# Altium schematic sheet Power input.SchDoc("Power input")
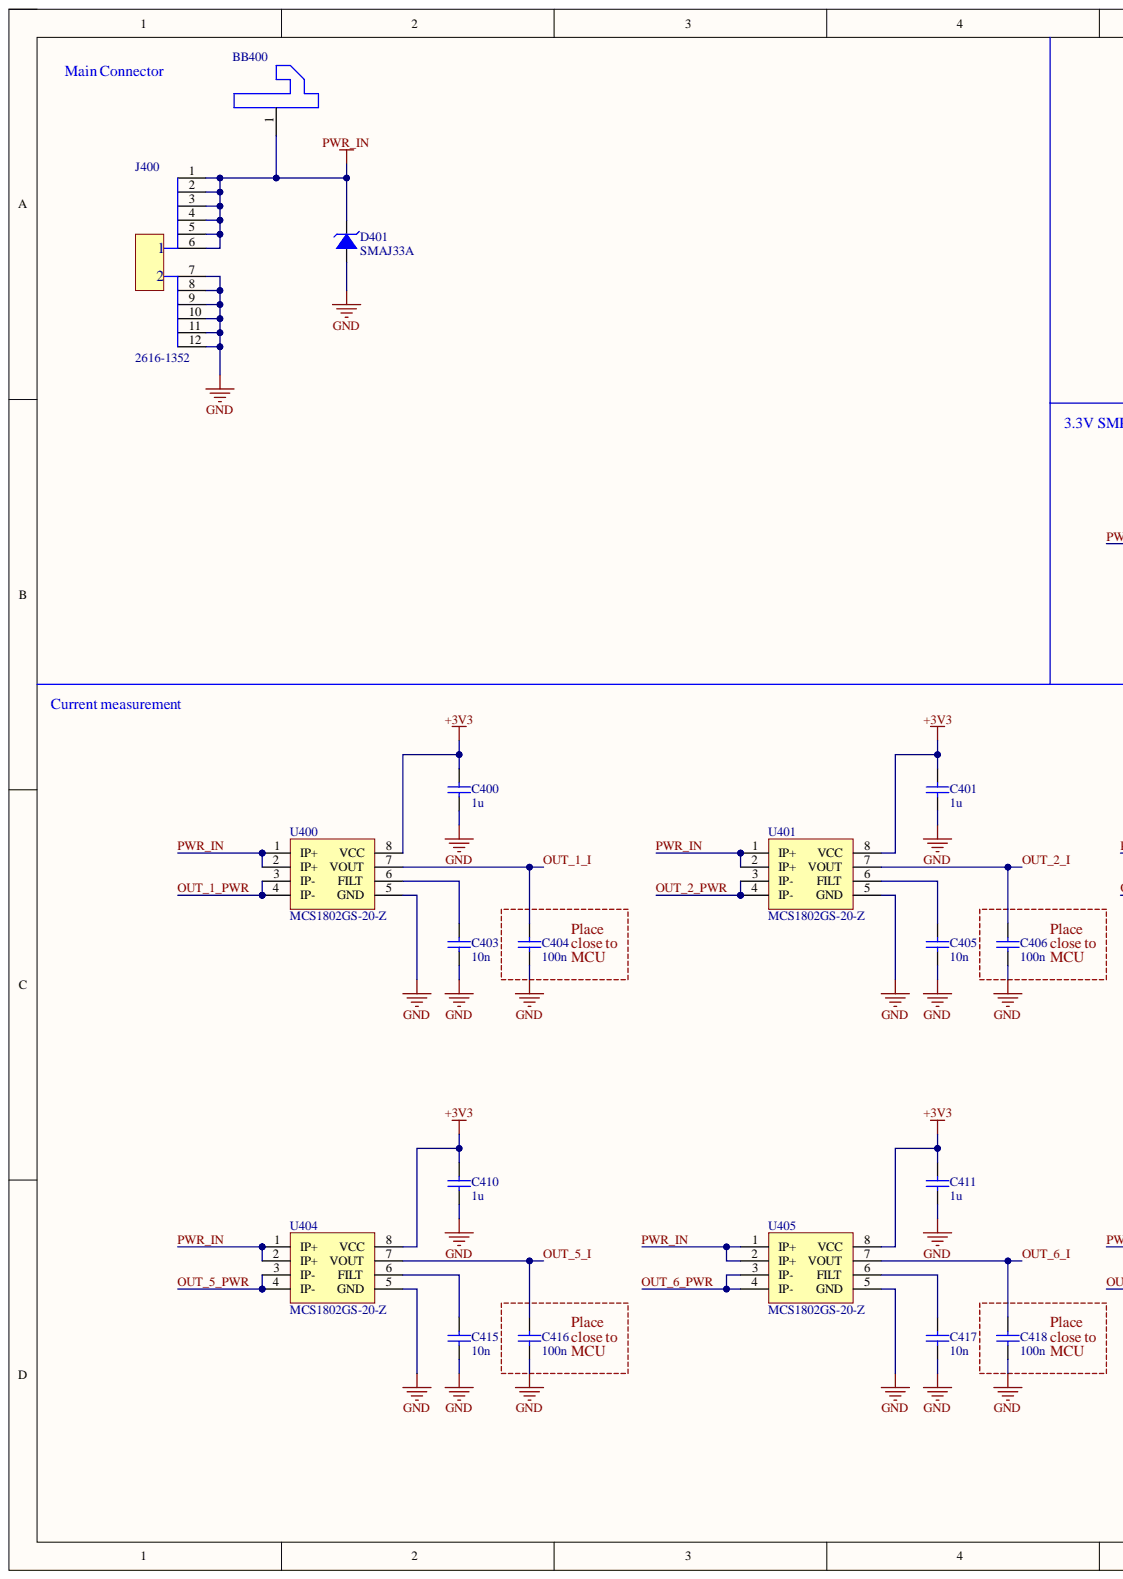
[diagram p0: Power input.SchDoc, left]
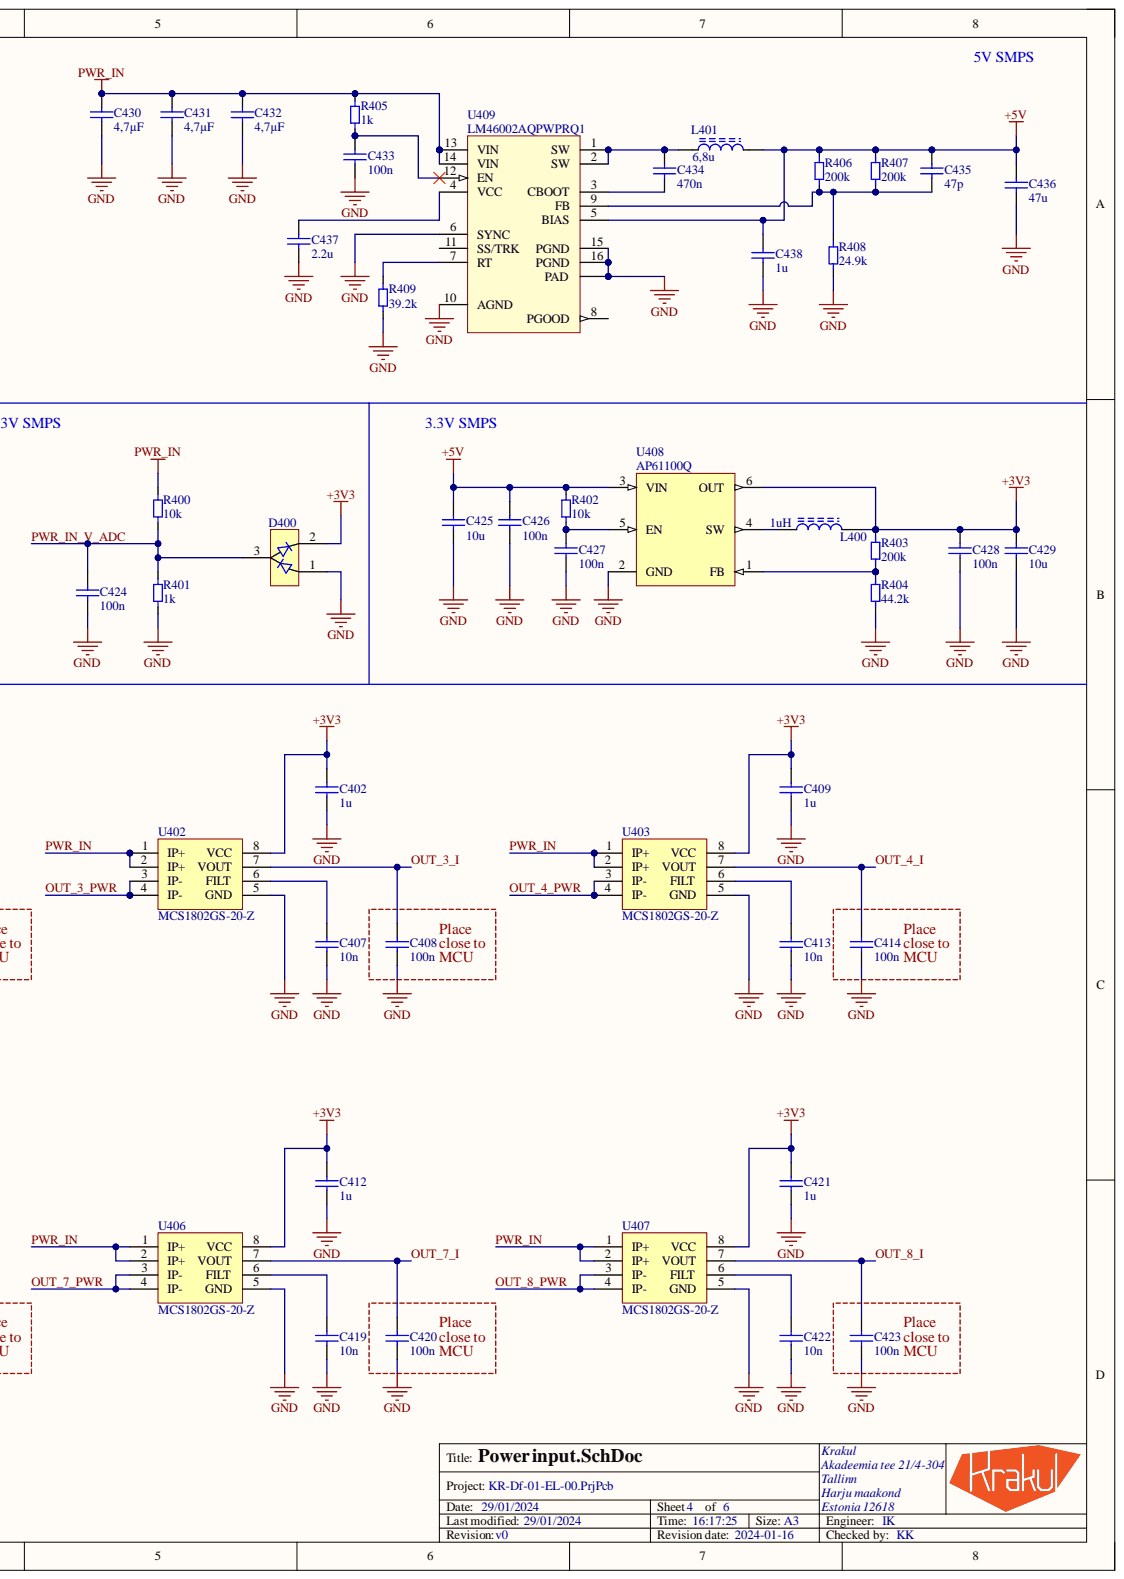
[diagram p1: Power input.SchDoc, right]

## Parts
BB400  Kr-Df-01 PWR BUSBAR  [Kr-Df-01 PWR BUSBAR footprint]  (value not drawn)
C400  1u  [MLCC_0603_M]
C401  1u  [MLCC_0603_M]
C402  1u  [MLCC_0603_M]
C403  10n  [MLCC_0402_M]
C404  100n  [MLCC_0402_M]
C405  10n  [MLCC_0402_M]
C406  100n  [MLCC_0402_M]
C407  10n  [MLCC_0402_M]
C408  100n  [MLCC_0402_M]
C409  1u  [MLCC_0603_M]
C410  1u  [MLCC_0603_M]
C411  1u  [MLCC_0603_M]
C412  1u  [MLCC_0603_M]
C413  10n  [MLCC_0402_M]
C414  100n  [MLCC_0402_M]
C415  10n  [MLCC_0402_M]
C416  100n  [MLCC_0402_M]
C417  10n  [MLCC_0402_M]
C418  100n  [MLCC_0402_M]
C419  10n  [MLCC_0402_M]
C420  100n  [MLCC_0402_M]
C421  1u  [MLCC_0603_M]
C422  10n  [MLCC_0402_M]
C423  100n  [MLCC_0402_M]
C424  100n  [MLCC_0402_M]
C425  10u  [MLCC_0603_M]
C426  100n  [MLCC_0402_M]
C427  100n  [MLCC_0402_M]
C428  100n  [MLCC_0402_M]
C429  10u  [MLCC_0603_M]
C430  value 4,7µF  [MLCC_1210_M]  (hidden comment: 4.7u)
C431  value 4,7µF  [MLCC_1210_M]  (hidden comment: 4.7u)
C432  value 4,7µF  [MLCC_1210_M]  (hidden comment: 4.7u)
C433  100n  [MLCC_0402_M]
C434  470n  [MLCC_0402_M]
C435  47p  [MLCC_0402_M]
C436  47u  [MLCC_1206_M]
C437  2.2u  [MLCC_0603_M]
C438  1u  [MLCC_0603_M]
D400  BAT6404WH6327XTSA1  [SOT323, SC70]  (value not drawn)
D401  SMAJ33A  [FP-SMAJ-MFG]
J400  2616-1352  [2616-1352-Footprint-1]
L400  value 1uH  Inductance: 1uH  [LQH3NPN]  (hidden comment: LQH3NPN1R0MMEL)
L401  value 6,8u  [SRP0420-6R8K-Footprint-1]  (hidden comment: SRP0420-6R8K)
R400  10k  [Resistor_0603_M]
R401  1k  [Resistor_0603_M]
R402  10k  [Resistor_0603_M]
R403  200k  [Resistor_0603_M]
R404  44.2k  [Resistor_0603_M]
R405  1k  [Resistor_0402_M]
R406  200k  [Resistor_0603_M]
R407  200k  [Resistor_0603_M]
R408  24.9k  [Resistor_0402_M]
R409  39.2k  [Resistor_0402_M]
U400  MCS1802GS-20-Z  [MCS1802GS-20-Z -Footprint-1]
U401  MCS1802GS-20-Z  [MCS1802GS-20-Z -Footprint-1]
U402  MCS1802GS-20-Z  [MCS1802GS-20-Z -Footprint-1]
U403  MCS1802GS-20-Z  [MCS1802GS-20-Z -Footprint-1]
U404  MCS1802GS-20-Z  [MCS1802GS-20-Z -Footprint-1]
U405  MCS1802GS-20-Z  [MCS1802GS-20-Z -Footprint-1]
U406  MCS1802GS-20-Z  [MCS1802GS-20-Z -Footprint-1]
U407  MCS1802GS-20-Z  [MCS1802GS-20-Z -Footprint-1]
U408  AP61100Q  [SOT-5X3]
U409  LM46002AQPWPRQ1  [HTSSOP-16]

## Nets
+3V3: C400.2 C401.2 C402.2 C409.2 C410.2 C411.2 C412.2 C421.2 C428.2 C429.2 D400.2 L400.2 R403.2 U400.8(VCC) U401.8(VCC) U402.8(VCC) U403.8(VCC) U404.8(VCC) U405.8(VCC) U406.8(VCC) U407.8(VCC) U408.6(OUT)
+5V: C425.2 C426.2 C435.2 C436.2 C438.2 L401.2 R402.2 R406.2 R407.2 U408.3(VIN) U409.5(BIAS)
GND: C400.1 C401.1 C402.1 C403.1 C404.1 C405.1 C406.1 C407.1 C408.1 C409.1 C410.1 C411.1 C412.1 C413.1 C414.1 C415.1 C416.1 C417.1 C418.1 C419.1 C420.1 C421.1 C422.1 C423.1 C424.1 C425.1 C426.1 C427.1 C428.1 C429.1 C430.2 C431.2 C432.2 C433.2 C436.1 C437.1 C438.1 D400.1 D401.2 J400.7 J400.8 J400.9 J400.10 J400.11 J400.12 R401.1 R404.1 R408.1 R409.1 U400.5(GND) U401.5(GND) U402.5(GND) U403.5(GND) U404.5(GND) U405.5(GND) U406.5(GND) U407.5(GND) U408.2(GND) U409.6(SYNC) U409.10(AGND) U409.15(PGND) U409.16(PGND) U409.17(PAD)
OUT_1_I: C404.2 U400.7(VOUT)
OUT_1_PWR: U400.3(IP-) U400.4(IP-)
OUT_2_I: C406.2 U401.7(VOUT)
OUT_2_PWR: U401.3(IP-) U401.4(IP-)
OUT_3_I: C408.2 U402.7(VOUT)
OUT_3_PWR: U402.3(IP-) U402.4(IP-)
OUT_4_I: C414.2 U403.7(VOUT)
OUT_4_PWR: U403.3(IP-) U403.4(IP-)
OUT_5_I: C416.2 U404.7(VOUT)
OUT_5_PWR: U404.3(IP-) U404.4(IP-)
OUT_6_I: C418.2 U405.7(VOUT)
OUT_6_PWR: U405.3(IP-) U405.4(IP-)
OUT_7_I: C420.2 U406.7(VOUT)
OUT_7_PWR: U406.3(IP-) U406.4(IP-)
OUT_8_I: C423.2 U407.7(VOUT)
OUT_8_PWR: U407.3(IP-) U407.4(IP-)
PWR_IN: BB400.1 C430.1 C431.1 C432.1 D401.1 J400.1 J400.2 J400.3 J400.4 J400.5 J400.6 R400.2 R405.2 U400.1(IP+) U400.2(IP+) U401.1(IP+) U401.2(IP+) U402.1(IP+) U402.2(IP+) U403.1(IP+) U403.2(IP+) U404.1(IP+) U404.2(IP+) U405.1(IP+) U405.2(IP+) U406.1(IP+) U406.2(IP+) U407.1(IP+) U407.2(IP+) U409.13(VIN) U409.14(VIN)
PWR_IN_V_ADC: C424.2 D400.3 R400.1 R401.2
NetC403_2: C403.2 U400.6(FILT)
NetC405_2: C405.2 U401.6(FILT)
NetC407_2: C407.2 U402.6(FILT)
NetC413_2: C413.2 U403.6(FILT)
NetC415_2: C415.2 U404.6(FILT)
NetC417_2: C417.2 U405.6(FILT)
NetC419_2: C419.2 U406.6(FILT)
NetC422_2: C422.2 U407.6(FILT)
NetC427_2: C427.2 R402.1 U408.5(EN)
NetC433_1: C433.1 R405.1 U409.12(EN)
NetC434_1: C434.1 U409.3(CBOOT)
NetC434_2: C434.2 L401.1 U409.1(SW) U409.2(SW)
NetC435_1: C435.1 R406.1 R407.1 R408.2 U409.9(FB)
NetC437_2: C437.2 U409.4(VCC)
NetL400_1: L400.1 U408.4(SW)
NetR403_1: R403.1 R404.2 U408.1(FB)
NetR409_2: R409.2 U409.7(RT)

Not connected: U409 8(PGOOD) 11(SS/TRK)

## Library data of the parts
BB400 = CMP-ROOT-01072-2: Custom PWR busbar for DefSecIntel fuse board. Cut from 1mm copper and solder to PCB; Manufacturer: Krakul; Part number: PWR_BUSBAR_1; Case/Package: Custom busbar; Component Kind: Standard; Library Name: Krakul Vault; Mount: SMD; Pins: 1; RoHS: Yes
C400-C402 C409-C412 C421 C438 = CMP-001-0642-2: MLCC, 0603, 1u, 10%, 10V, X7R; Manufacturer: Any; Capacitor Type: Ceramic; Value: 1µF; Case/Package: 0603; Component Kind: Standard; Dielectric: X7R; Mount: SMD; Part number: MLCC, 0603, 1u, 10%, 10V, X7R; RoHS: Yes; Tolerance: 10%; Voltage: 10V; Library Name: Krakul Vault
C403 C405 C407 C413 C415 C417 C419 C422 = CMP-0001-00209-2: MLCC, 0402, 10n, 10%, 50V, X5R; Manufacturer: Any; Capacitor Type: Ceramic; Value: 10nF; Case/Package: 0402; Component Kind: Standard; Dielectric: X5R; Mount: SMD; Part number: MLCC, 0402, 10n, 10%, 50V, X5R; RoHS: Yes; Tolerance: 10%; Voltage: 50V; Library Name: Krakul Vault
C404 C406 C408 C414 C416 C418 C420 C423 C424 C426-C428 = CMP-001-1256-2: MLCC, 0402, 100n, 10%, 10V, X5R; Manufacturer: Any; Capacitor Type: Ceramic; Value: 100nF; Case/Package: 0402; Component Kind: Standard; Dielectric: X5R; Mount: SMD; Part number: MLCC, 0402, 100n, 10%, 10V, X5R; RoHS: Yes; Tolerance: 10%; Voltage: 10V; Library Name: Krakul Vault
C425 C429 = CMP-001-1355-1: MLCC, 0603, 10u, 10%, 25V, X5R; Manufacturer: Any; Capacitor Type: Ceramic; Value: 10µF; Case/Package: 0603; Component Kind: Standard; Dielectric: X5R; Mount: SMD; Part number: MLCC, 0603, 10u, 10%, 25V, X5R; RoHS: Yes; Voltage: 25V; Library Name: Krakul Vault
C430-C432 = CMP-001-1296-1: MLCC, 1210, 4.7u, 10%, 100V, X7S; Manufacturer: Any; Capacitance: 4.7uF; Capacitor Type: Ceramic; Case Code (Imperial): 1210; Case Code (Metric): 3225; Case/Package: 1210; Component Kind: Standard; Dielectric: X7S; Max Operating Temperature: 125°C; Min Operating Temperature: -55°C; Mount: Surface Mount; Packaging: Tape and Reel; Part number: MLCC, 1210, 4.7u, 10%, 100V, X7S; RoHS: Yes; Tolerance: 10%; Voltage: 100V; Voltage Rating: 100V; Library Name: Krakul Vault
C433 = CMP-0001-00196-2: MLCC, 0402, 100n, 10%, 50V, X7R; Manufacturer: Any; Capacitor Type: Ceramic; Value: 100nF; Case/Package: 0402; Component Kind: Standard; Dielectric: X7R; Mount: SMD; Part number: MLCC, 0402, 100n, 10%, 50V, X7R; RoHS: Yes; Tolerance: 10%; Voltage: 50V; Library Name: Krakul Vault
C434 = CMP-0001-00217-2: MLCC, 0402, 470n, 10%, 50V, X5R; Manufacturer: Any; Capacitor Type: Ceramic; Value: 470nF; Case/Package: 0402; Component Kind: Standard; Dielectric: X5R; Mount: SMD; Part number: MLCC, 0402, 470n, 10%, 50V, X5R; RoHS: Yes; Tolerance: 10%; Voltage: 50V; Library Name: Krakul Vault
C435 = CMP-0001-00149-2: MLCC, 0402, 47p, 10%, 50V, X7R; Manufacturer: Any; Capacitor Type: Ceramic; Value: 47pF; Case/Package: 0402; Component Kind: Standard; Dielectric: X7R; Mount: SMD; Part number: MLCC, 0402, 47p, 10%, 50V, X7R; RoHS: Yes; Tolerance: 10%; Voltage: 50V; Library Name: Krakul Vault
C436 = CMP-001-1106-2: MLCC, 1206, 47u, 10%, 10V, X5R; Manufacturer: Any; Capacitor Type: Ceramic; Value: 47µF; Case/Package: 1206; Component Kind: Standard; Dielectric: X5R; Mount: SMD; Part number: MLCC, 1206, 47u, 10%, 10V, X5R; RoHS: Yes; Tolerance: 10%; Voltage: 10V; Library Name: Krakul Vault
C437 = CMP-001-1459-1: MLCC, 0603, 2.2u, 10%, 16V, X5R; Manufacturer: Any; Capacitor Type: Ceramic; Value: 2.2µF; Case/Package: 0603; Component Kind: Standard; Dielectric: X5R; Mount: SMD; Part number: MLCC, 0603, 2.2u, 10%, 16V, X5R; RoHS: Yes; Tolerance: 10%; Voltage: 16V; Library Name: Krakul Vault
D400 = CMP-ROOT-00071-1: Diode Array 1 Pair Series Connection Schottky 40V 120mA Surface Mount SC-70, SOT-323; Manufacturer: Infineon; Forward Current: 250mA; Forward Voltage: 750mV; Peak Non-Repetitive Surge Current: 800mA; Reverse Recovery Time: 5ns; Average Rectified Current: 120mA; Case/Package: SOT-323; Component Kind: Standard; Halogen Free: Halogen Free; Lead Free: Lead Free; Max Operating Temperature: 150°C; Max Repetitive Reverse Voltage (Vrrm): 40V; Max Reverse Voltage (DC): 40V; Min Operating Temperature: -55°C; Mount: Surface Mount; Number of Pins: 3; Packaging: Tape and Reel; Part number: BAT6404WH6327XTSA1; Pins: 3; Radiation Hardening: No; RoHS: Yes; Library Name: Krakul Vault
D401 = CMP-ROOT-01076-1: SMAJ Series 53.3 V 0.4 kW Surface Mount Uni-Directional TVS Diode - DO-214AC; Manufacturer: Littelfuse; Clamping Voltage: 53.3V; Diode Type: ZENER; Direction: Unidirectional; Leakage Current: 1uA; Max Breakdown Voltage: 40.6V; Max Power Dissipation: 3.3W; Min Breakdown Voltage: 36.7V; Number of Elements: 1; Peak Pulse Current: 7.5A; Peak Pulse Power: 400W; Power Line Protection: No; Reverse Standoff Voltage: 33V; Test Current: 1mA; Case/Package: DO-214AC; Clamping Voltage-Max: 53.3V; Component Kind: Standard; Height: 2.087mm; Lead Free: Lead Free; Length: 4.5mm; Max Operating Temperature: 150°C; Max Reverse Leakage Current: 1uA; Min Operating Temperature: -65°C; Mount: SMD; Mounting Technology: Surface Mount; Number of Unidirectional Channels: 1; Packaging: Tape and Reel; Part number: SMAJ33A; Pins: 2; Polarity: Unidirectional; Power Dissipation: 400W; Reach SVHC Compliant: Unknown; Reverse Breakdown Voltage: 36.7V; RoHS: Yes; RoHS Compliant: Yes; Series: SMAJ; Voltage Rating (DC): 33V; Width: 2.79mm; Library Name: Krakul Vault
J400 = CMP-ROOT-01061-1: Lever actuated terminal block, Wire-to-board. 78A, 300V, 4-18 AWG; Manufacturer: WAGO; Component Kind: Standard; Housing Color: Grey; Mount: TH; Part number: 2616-1352; Pins: 2; RoHS: Yes; Library Name: Krakul Vault
L400 = CMP-ROOT-00537-1: 1µH Shielded Wirewound Inductor 1.6A 30mOhm Max 1212 (3030 Metric); Manufacturer: Murata Electronics; Core Material: Ferrite; Current Rating: 3A; DC Resistance (DCR): 30mR; Saturation Current: 2.35A; Self Resonant Frequency: 100MHz; Test Frequency: 1MHz; Tolerance: 20%; Case Code (Imperial): 1212; Case Code (Metric): 3030; Case/Package: 1212; Component Kind: Standard; Composition: Wirewound; Max Operating Temperature: 85°C; Min Operating Temperature: -40°C; Mount: Surface Mount; Packaging: Tape and Reel; Part number: LQH3NPN1R0MMEL; RoHS: Yes; Shielding: Shielded; Library Name: Krakul Vault
L401 = CMP-ROOT-01075-1: Inductor Power Shielded Wirewound 6.8uH 10% 100KHz 27Q-Factor Metal Alloy Powder 2.6A 0.117Ohm DCR T/R; Manufacturer: Bourns; Case/Package: 4x4mm; Component Kind: Standard; Mount: SMD; Part number: SRP0420-6R8K; Pins: 2; RoHS: Yes; Library Name: Krakul Vault
R400 R402 = CMP-001-0101-2: Resistor, 0603, 10k, 1%, 1/10W; Manufacturer: Any; Power: 100mW; Case/Package: 0603; Component Kind: Standard; Mount: SMD; Part number: Resistor, 0603, 10k, 1%, 1/10W; RoHS: Yes; Tolerance: 1%; Value: 10k; Library Name: Krakul Vault
R401 = CMP-0001-00237-1: Resistor, 0603, 1k, 0.1%, 1/16W; Manufacturer: Any; Case/Package: 0603; Component Kind: Standard; Mount: SMD; Part number: Any; RoHS: Yes; Value: 1k; Library Name: Krakul Vault
R403 R406 R407 = CMP-001-0132-2: Resistor, 0603, 200k, 1%, 1/10W; Manufacturer: Any; Power: 100mW; Case/Package: 0603; Component Kind: Standard; Mount: SMD; Part number: Resistor, 0603, 200k, 1%, 1/10W; RoHS: Yes; Tolerance: 1%; Value: 200k; Library Name: Krakul Vault
R404 = CMP-001-1616-1: Resistor, 0603, 44.2k, 0.1%, 1/10W; Manufacturer: Any; Power: 100mW; Case/Package: 0603; Component Kind: Standard; Mount: SMD; Part number: Resistor, 0603, 44.2k, 0.1%, 1/10W; RoHS: Yes; Tolerance: 0.1%; Value: 44.2k; Library Name: Krakul Vault
R405 = CMP-001-0340-2: Resistor, 0402, 1k, 1%, 1/16W; Manufacturer: Any; Power: 62mW; Case/Package: 0402; Component Kind: Standard; Mount: SMD; Part number: Resistor, 0402, 1k, 1%, 1/16W; RoHS: Yes; Tolerance: 1%; Value: 1k; Library Name: Krakul Vault
R408 = CMP-0001-00027-1: Resistor, 0402, 24.9k, 1%, 1/10W; Manufacturer: Any; Case/Package: 0402; Component Kind: Standard; Mount: SMD; Part number: Any; RoHS: Yes; Value: 24.9k; Library Name: Krakul Vault
R409 = CMP-0001-00015-2: Resistor, 0402, 39.2k, 1%, 1/10W; Manufacturer: Any; Case/Package: 0402; Component Kind: Standard; Mount: SMD; Part number: Resistor, 0402, 39.2k, 1%, 1/10W; RoHS: Yes; Value: 39.2k; Library Name: Krakul Vault
U400-U407 = CMP-ROOT-01063-2: Hall Effect-Based Linear Current Sensor IC ±20A 66mV/A 100kHz 8-Pin SOIC T/R; Manufacturer: MPS; Case/Package: SOIC-8; Component Kind: Standard; Mount: SMD; Part number: MCS1802GS-20-Z; Pins: 8; RoHS: Yes; Sensitivity: 66mV/A; Library Name: Krakul Vault
U408 = CMP-ROOT-00784-1: Switching Voltage Regulators DCDC Conv LV Buck SOT563 T&R 3K; Manufacturer: Diodes Incorporated; Part number: AP61102Z6-7; Case/Package: SOT-563-6; Component Kind: Standard; Mount: SMD; RoHS: Yes; Library Name: Krakul Vault
U409 = CMP-ROOT-00198-2: Manufacturer: Texas Instruments; Max Input Voltage: 60V; Max Output Voltage: 28V; Min Input Voltage: 3.5V; Min Output Voltage: 1V; Number of Outputs: 1; Output Configuration: Positive; Output Current: 2A; Output Type: Adjustable; Switching Frequency: 2.2MHz; Synchronous Rectifier: Yes; Case/Package: 16HTSSOP; Component Kind: Standard; Description: LM46002AQPWPRQ1; Max Operating Temperature: 125°C; Min Operating Temperature: -40°C; Mount: Surface Mount; Packaging: Tape and Reel; Part number: LM46002AQPWPRQ1; Pins: 16; RoHS: Yes; Library Name: Krakul Vault
